# Altium schematic sheet LPS28DFWTR-V1.0.SchDoc
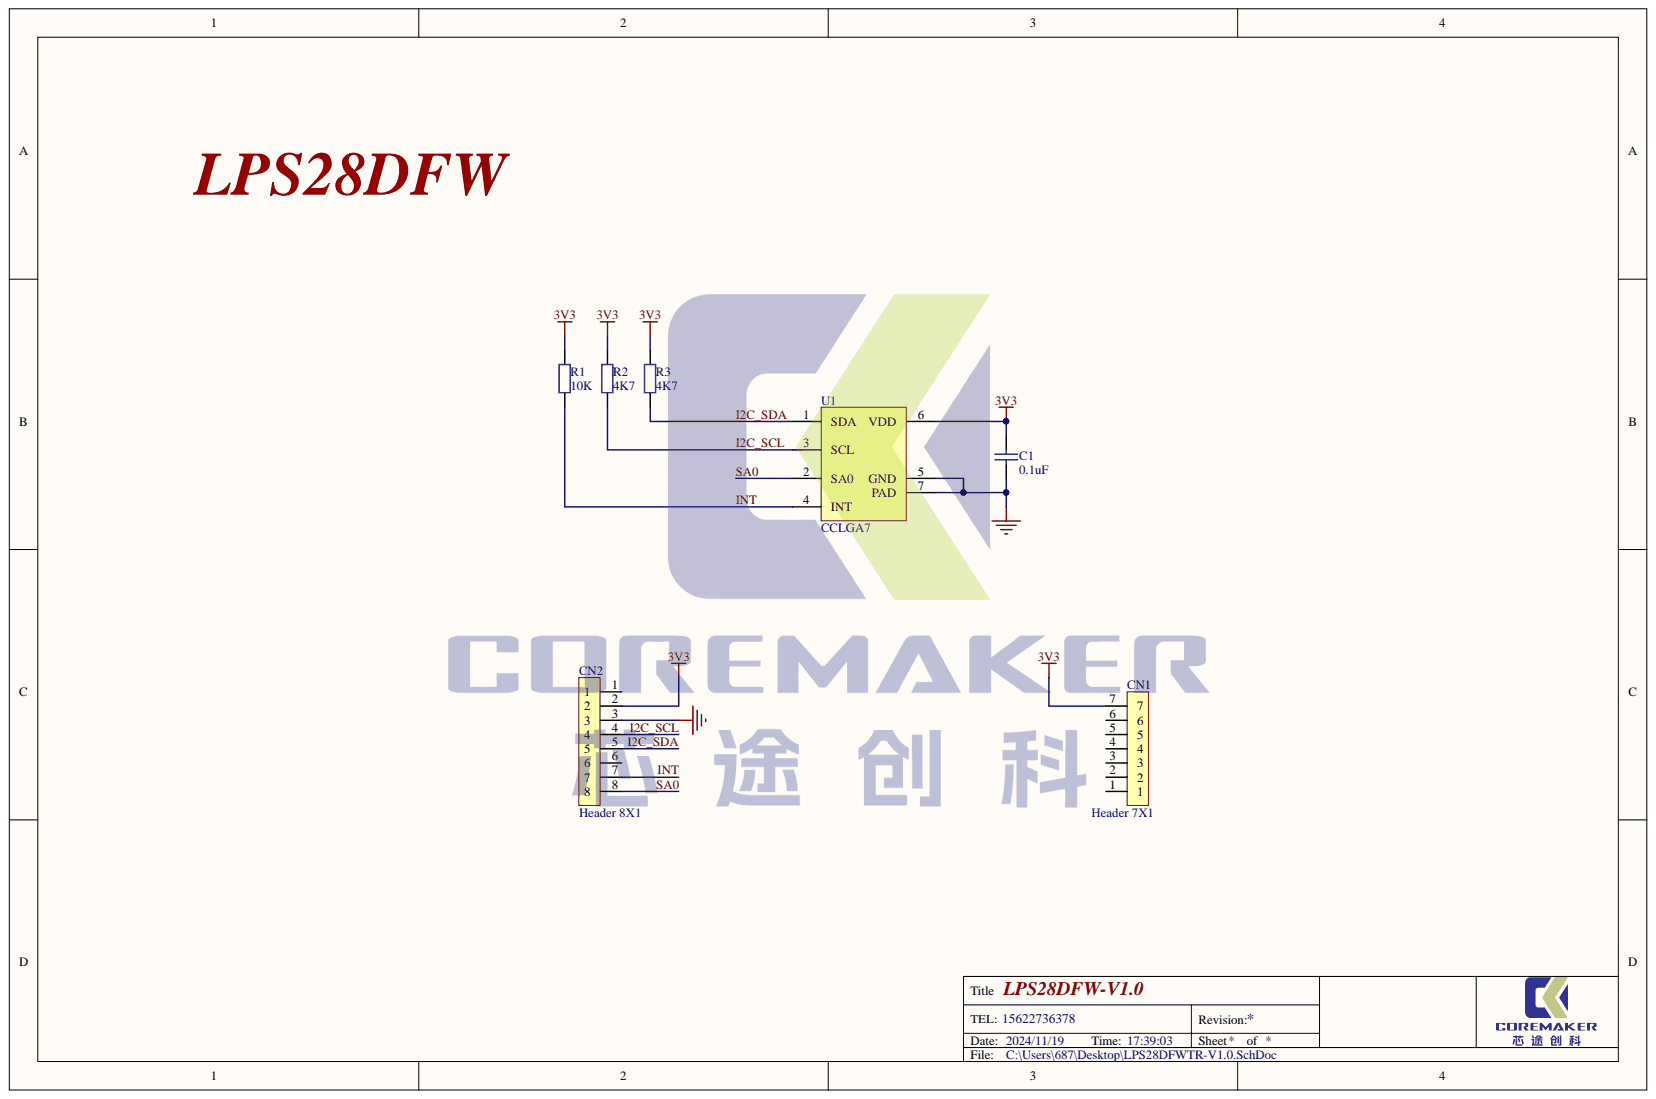
[diagram p0: LPS28DFWTR-V1.0.SchDoc]

## Parts
C1  0.1uF  [CAP_0603]
CN1  Header 7X1  [1X7PIN]
CN2  Header 8X1  [1X8PIN]
R1  10K  [RES_0603]
R2  4K7  [RES_0603]
R3  4K7  [RES_0603]
U1  CCLGA7  [CCLGA7]

## Nets
3V3: C1.1 CN1.7 CN2.2 R1.1 R2.2 R3.2 U1.6
GND: C1.2 CN2.3 U1.5 U1.7
I2C_SCL: CN2.4 R2.1 U1.3
I2C_SDA: CN2.5 R3.1 U1.1
INT: CN2.7 R1.2 U1.4
SA0: CN2.8 U1.2

Not connected: CN1 1 2 3 4 5 6; CN2 1 6

## Library data of the parts
C1 = CAP: Component Kind: Standard; Description: CAP; Library Name: ST_2.4G.SchLib
R1-R3 = Res: Component Kind: Standard; Description: Res; Library Name: ST_2.4G.SchLib
CN1 = Header 7X1: Component Kind: Standard; Description: Header 7X1; Library Name: LPS28DFWTR.SchLib
CN2 = Header 8X1: Component Kind: Standard; Description: Header 8X1; Library Name: 1019_WB55_Senser.SchLib
U1 = CCLGA7: Component Kind: Standard; Description: CCLGA7; Library Name: LPS28DFWTR.SchLib
